# Altium schematic sheet mainboard2.SchDoc(mainboard2)
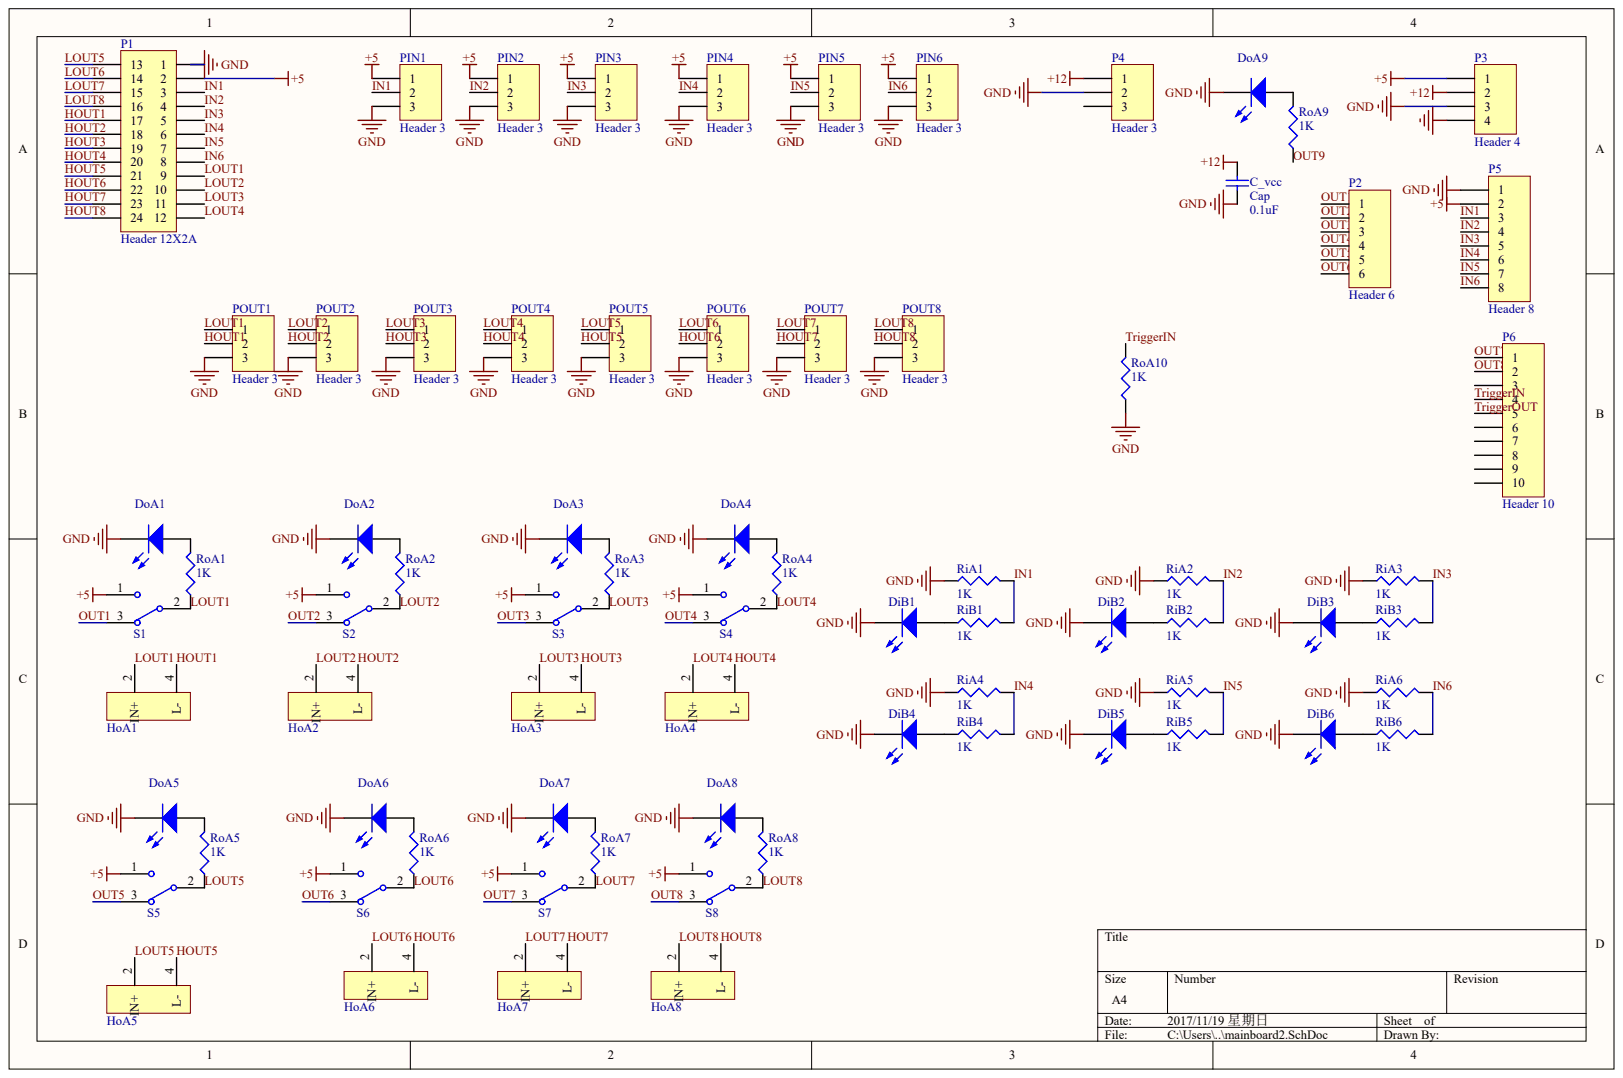
[diagram p0: mainboard2.SchDoc]

## Parts
C_vcc  Cap  value 0.1uF
DiB1  LED1  (value not drawn)
DiB2  LED1  (value not drawn)
DiB3  LED1  (value not drawn)
DiB4  LED1  (value not drawn)
DiB5  LED1  (value not drawn)
DiB6  LED1  (value not drawn)
DoA1  LED1  (value not drawn)
DoA2  LED1  (value not drawn)
DoA3  LED1  (value not drawn)
DoA4  LED1  (value not drawn)
DoA5  LED1  (value not drawn)
DoA6  LED1  (value not drawn)
DoA7  LED1  (value not drawn)
DoA8  LED1  (value not drawn)
DoA9  LED1  (value not drawn)
HoA1  H3MB-052D  (value not drawn)
HoA2  H3MB-052D  (value not drawn)
HoA3  H3MB-052D  (value not drawn)
HoA4  H3MB-052D  (value not drawn)
HoA5  H3MB-052D  (value not drawn)
HoA6  H3MB-052D  (value not drawn)
HoA7  H3MB-052D  (value not drawn)
HoA8  H3MB-052D  (value not drawn)
P1  Header 12X2A
P2  Header 6
P3  Header 4
P4  Header 3
P5  Header 8
P6  Header 10
PIN1  Header 3
PIN2  Header 3
PIN3  Header 3
PIN4  Header 3
PIN5  Header 3
PIN6  Header 3
POUT1  Header 3
POUT2  Header 3
POUT3  Header 3
POUT4  Header 3
POUT5  Header 3
POUT6  Header 3
POUT7  Header 3
POUT8  Header 3
RiA1  value 1K  (hidden comment: Res3)
RiA2  value 1K  (hidden comment: Res3)
RiA3  value 1K  (hidden comment: Res3)
RiA4  value 1K  (hidden comment: Res3)
RiA5  value 1K  (hidden comment: Res3)
RiA6  value 1K  (hidden comment: Res3)
RiB1  value 1K  (hidden comment: Res3)
RiB2  value 1K  (hidden comment: Res3)
RiB3  value 1K  (hidden comment: Res3)
RiB4  value 1K  (hidden comment: Res3)
RiB5  value 1K  (hidden comment: Res3)
RiB6  value 1K  (hidden comment: Res3)
RoA1  value 1K  (hidden comment: Res3)
RoA2  value 1K  (hidden comment: Res3)
RoA3  value 1K  (hidden comment: Res3)
RoA4  value 1K  (hidden comment: Res3)
RoA5  value 1K  (hidden comment: Res3)
RoA6  value 1K  (hidden comment: Res3)
RoA7  value 1K  (hidden comment: Res3)
RoA8  value 1K  (hidden comment: Res3)
RoA9  value 1K  (hidden comment: Res3)
RoA10  value 1K  (hidden comment: Res3)
S1  SW-SPDT  (value not drawn)
S2  SW-SPDT  (value not drawn)
S3  SW-SPDT  (value not drawn)
S4  SW-SPDT  (value not drawn)
S5  SW-SPDT  (value not drawn)
S6  SW-SPDT  (value not drawn)
S7  SW-SPDT  (value not drawn)
S8  SW-SPDT  (value not drawn)

## Nets
+5: P1.2 P3.1 P5.2 PIN1.1 PIN2.1 PIN3.1 PIN4.1 PIN5.1 PIN6.1 S1.1 S2.1 S3.1 S4.1 S5.1 S6.1 S7.1 S8.1
+12: C_vcc.2 HoA1.3 HoA2.3 HoA3.3 HoA4.3 HoA5.3 HoA6.3 HoA7.3 HoA8.3 P3.2 P4.1
GND: C_vcc.1 DiB1.2 DiB2.2 DiB3.2 DiB4.2 DiB5.2 DiB6.2 DoA1.2 DoA2.2 DoA3.2 DoA4.2 DoA5.2 DoA6.2 DoA7.2 DoA8.2 DoA9.2 HoA1.1 HoA2.1 HoA3.1 HoA4.1 HoA5.1 HoA6.1 HoA7.1 HoA8.1 P1.1 P3.3 P3.4 P4.2 P5.1 PIN1.3 PIN2.3 PIN3.3 PIN4.3 PIN5.3 PIN6.3 POUT1.3 POUT2.3 POUT3.3 POUT4.3 POUT5.3 POUT6.3 POUT7.3 POUT8.3 RiA1.1 RiA2.1 RiA3.1 RiA4.1 RiA5.1 RiA6.1 RoA10.1
HOUT1: HoA1.4 P1.17 POUT1.2
HOUT2: HoA2.4 P1.18 POUT2.2
HOUT3: HoA3.4 P1.19 POUT3.2
HOUT4: HoA4.4 P1.20 POUT4.2
HOUT5: HoA5.4 P1.21 POUT5.2
HOUT6: HoA6.4 P1.22 POUT6.2
HOUT7: HoA7.4 P1.23 POUT7.2
HOUT8: HoA8.4 P1.24 POUT8.2
IN1: P1.3 P5.3 PIN1.2 RiA1.2 RiB1.2
IN2: P1.4 P5.4 PIN2.2 RiA2.2 RiB2.2
IN3: P1.5 P5.5 PIN3.2 RiA3.2 RiB3.2
IN4: P1.6 P5.6 PIN4.2 RiA4.2 RiB4.2
IN5: P1.7 P5.7 PIN5.2 RiA5.2 RiB5.2
IN6: P1.8 P5.8 PIN6.2 RiA6.2 RiB6.2
LOUT1: HoA1.2 P1.9 POUT1.1 RoA1.1 S1.2
LOUT2: HoA2.2 P1.10 POUT2.1 RoA2.1 S2.2
LOUT3: HoA3.2 P1.11 POUT3.1 RoA3.1 S3.2
LOUT4: HoA4.2 P1.12 POUT4.1 RoA4.1 S4.2
LOUT5: HoA5.2 P1.13 POUT5.1 RoA5.1 S5.2
LOUT6: HoA6.2 P1.14 POUT6.1 RoA6.1 S6.2
LOUT7: HoA7.2 P1.15 POUT7.1 RoA7.1 S7.2
LOUT8: HoA8.2 P1.16 POUT8.1 RoA8.1 S8.2
OUT1: P2.1 S1.3
OUT2: P2.2 S2.3
OUT3: P2.3 S3.3
OUT4: P2.4 S4.3
OUT5: P2.5 S5.3
OUT6: P2.6 S6.3
OUT7: P6.1 S7.3
OUT8: P6.2 S8.3
OUT9: RoA9.1
TRIGGERIN: P6.4 RoA10.2
TRIGGEROUT: P6.5
NetDiB1_1: DiB1.1 RiB1.1
NetDiB2_1: DiB2.1 RiB2.1
NetDiB3_1: DiB3.1 RiB3.1
NetDiB4_1: DiB4.1 RiB4.1
NetDiB5_1: DiB5.1 RiB5.1
NetDiB6_1: DiB6.1 RiB6.1
NetDoA1_1: DoA1.1 RoA1.2
NetDoA2_1: DoA2.1 RoA2.2
NetDoA3_1: DoA3.1 RoA3.2
NetDoA4_1: DoA4.1 RoA4.2
NetDoA5_1: DoA5.1 RoA5.2
NetDoA6_1: DoA6.1 RoA6.2
NetDoA7_1: DoA7.1 RoA7.2
NetDoA8_1: DoA8.1 RoA8.2
NetDoA9_1: DoA9.1 RoA9.2
1:

Not connected: P4 3; P6 3 6 7 8 9 10

## Library data of the parts
C_vcc DiB1-DiB6 DoA1-DoA9 HoA1-HoA8 P1-P6 PIN1-PIN6 POUT1-POUT8 RiA1-RiA6 RiB1-RiB6 RoA1-RoA10 S1-S8 = (no symbol name)
  C_vcc: Published: 8-Jun-2000; LatestRevisionDate: 17-Jul-2002; LatestRevisionNote: Re-released for DXP Platform.; PackageReference: RAD-0.3
  DiB1-DiB6 DoA1-DoA9: Published: 8-Jun-2000; LatestRevisionDate: 08-Jul-2005; LatestRevisionNote: Mech Layer 1 removed.; PackageReference: LED-1
  P1-P6 PIN1-PIN6 POUT1-POUT8: LatestRevisionDate: 17-Jul-2002; LatestRevisionNote: Re-released for DXP Platform.
  RiA1-RiA6 RiB1-RiB6 RoA1-RoA10: Published: 8-Jun-2000; LatestRevisionDate: 29-May-2009; LatestRevisionNote: IPC-7351 Footprint Added.; PackageReference: J1-0603; PackageDescription: Chip Resistor
  S1-S8: Published: 24-Mar-1999; LatestRevisionDate: 17-Dec-2003; LatestRevisionNote: Schematic revised, PCB Footprint replaced.; DatasheetVersion: 05-Dec-2000; PackageReference: TL36WW15050; ComponentLink1Description: Manufacturer Link; ComponentLink1URL: http://www.apem.com
  C_vcc DiB1-DiB6 DoA1-DoA9 P1-P6 PIN1-PIN6 POUT1-POUT8 RiA1-RiA6 RiB1-RiB6 RoA1-RoA10 S1-S8: Publisher: Altium Limited
